# Altium schematic sheet Ardu_gen_control_single_button.SchDoc
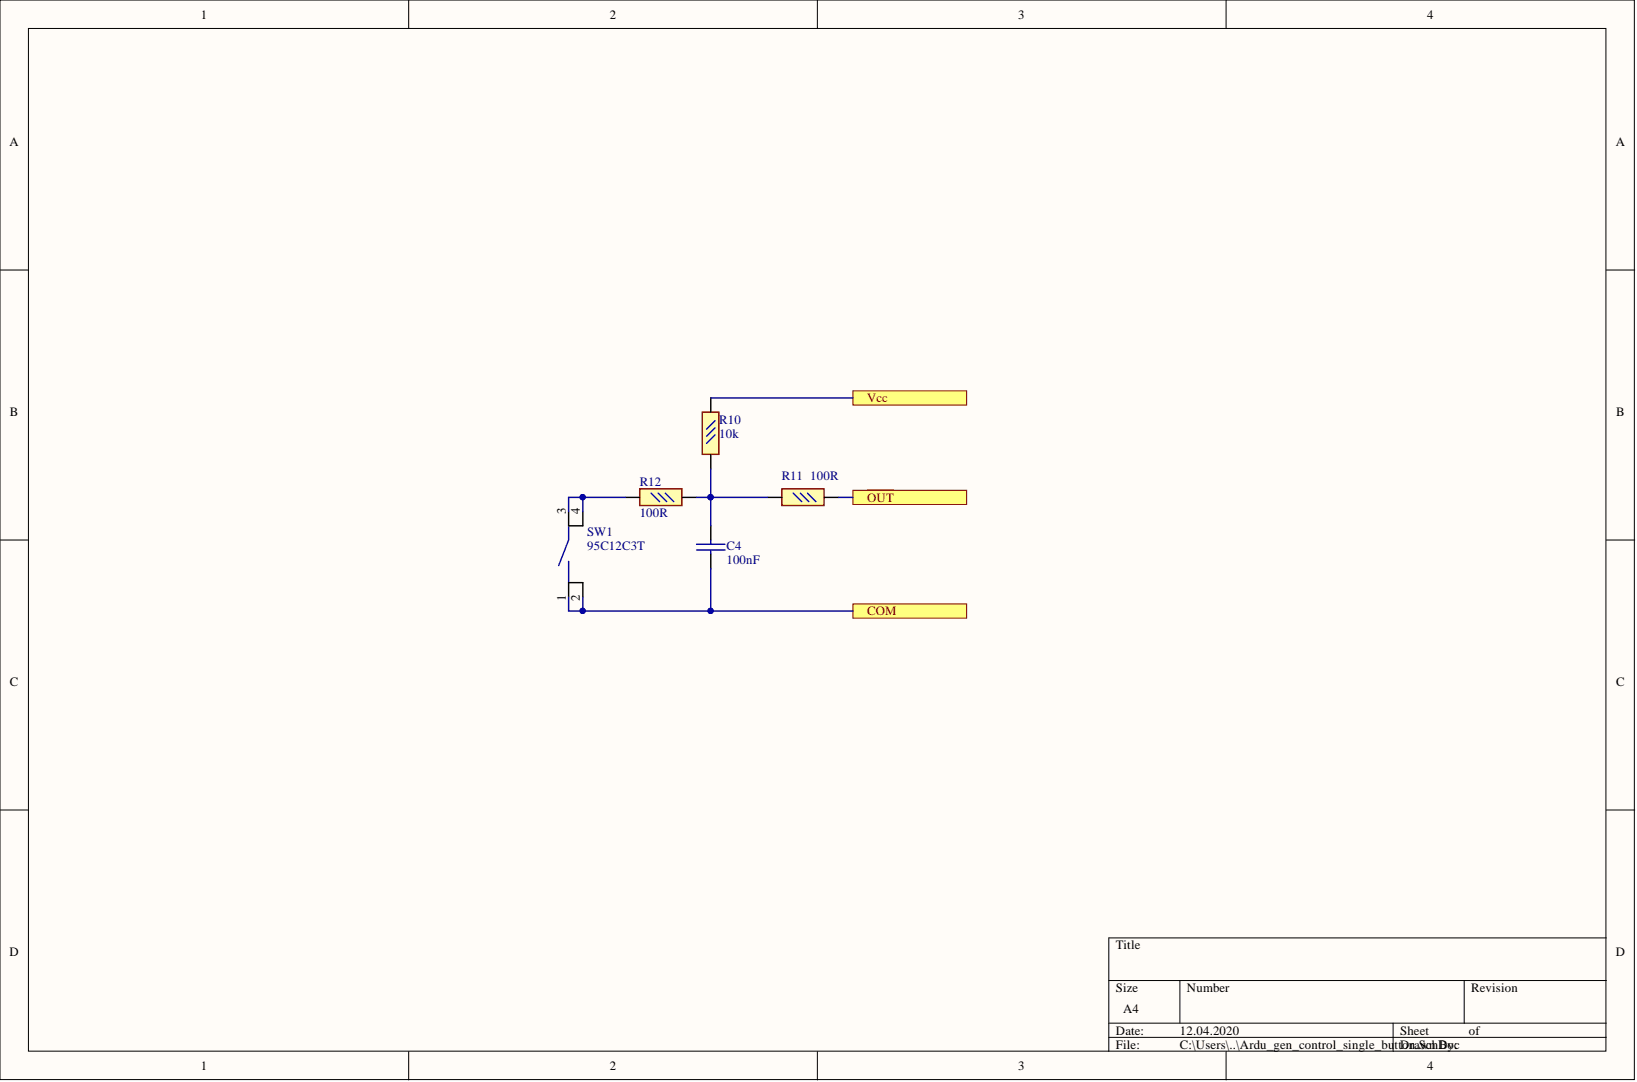
[diagram p0: Ardu_gen_control_single_button.SchDoc]

## Parts
C4  100nF  [0603c]
R10  10k  [0603r]
R11  100R  [0603r]
R12  100R  [0603r]
SW1  95C12C3T  [SWICH5B]

## Ports
COM, Vcc, ~{OUT}

## Nets
~{OUT}: R11.1 ; port ~{OUT}
COM: C4.2 SW1.1 SW1.2 ; port COM
Vcc: R10.1 ; port Vcc
NetC4_1: C4.1 R10.2 R11.2 R12.1
NetR12_2: R12.2 SW1.3 SW1.4

## Library data of the parts
C4 = 100nF 25V 0603: Company: Yageo; ID: B1153B11114; Order Code: CC0603KRX7R8BB104; TSKD: FS-CM_StandDet_Capacitor_CeramicSMD(100nF_25_10%_0603_X7R); Type: Capacitor; Component Kind: Standard; Description: 100nF 25V 0603; Supplier 1: Digi-Key; Supplier Part Number 1: 311-1341-1-ND; Value: 100nF; Library Name: CAPACITOR_dropbox.SchLib
R10 = 10k 0603 5%: Company: Yageo; ID: B112CV1113Y; Order Code: RC0603JR-0710KL; TSKD: FS-CM_StandDet_Resistor_SMD(10K_5%_100_0603); Component Kind: Standard; Description: 10k 0603 5%; Library Name: RESISTORs_Home.SchLib; Supplier 1: Digi-Key; Supplier Part Number 1: 311-10KGRCT-ND; Type: Resistor; Value: 10k
R11 R12 = 100R 0603 1%: Company: Yageo; ID: B112CV1117V; Order Code: RC0603FR-07100RL; TSKD: FS-CM_StandDet_Resistor_SMD(100R_1%_100_0603); Component Kind: Standard; Description: 100R 0603 1%; Library Name: RESISTORs_Home.SchLib; Supplier 1: Digi-Key; Supplier Part Number 1: 311-100HRCT-ND; Type: Resistor; Value: 100R
SW1 = 95C12C3T: Tactile switch 12x12mm Std Ht 7.3 Gen Purpose 130g; Company: Grayhill; Mountig: TH; Component Kind: Standard; ComponentLink1Description: PDF; ComponentLink1URL: https://eu.mouser.com/datasheet/2/626/Tact_95C12-335318.pdf; Order Code: 95C12C3T; Type: Switch; Library Name: COMUTAC_Library.SchLib
